# Altium schematic sheet Protection circuit.SchDoc
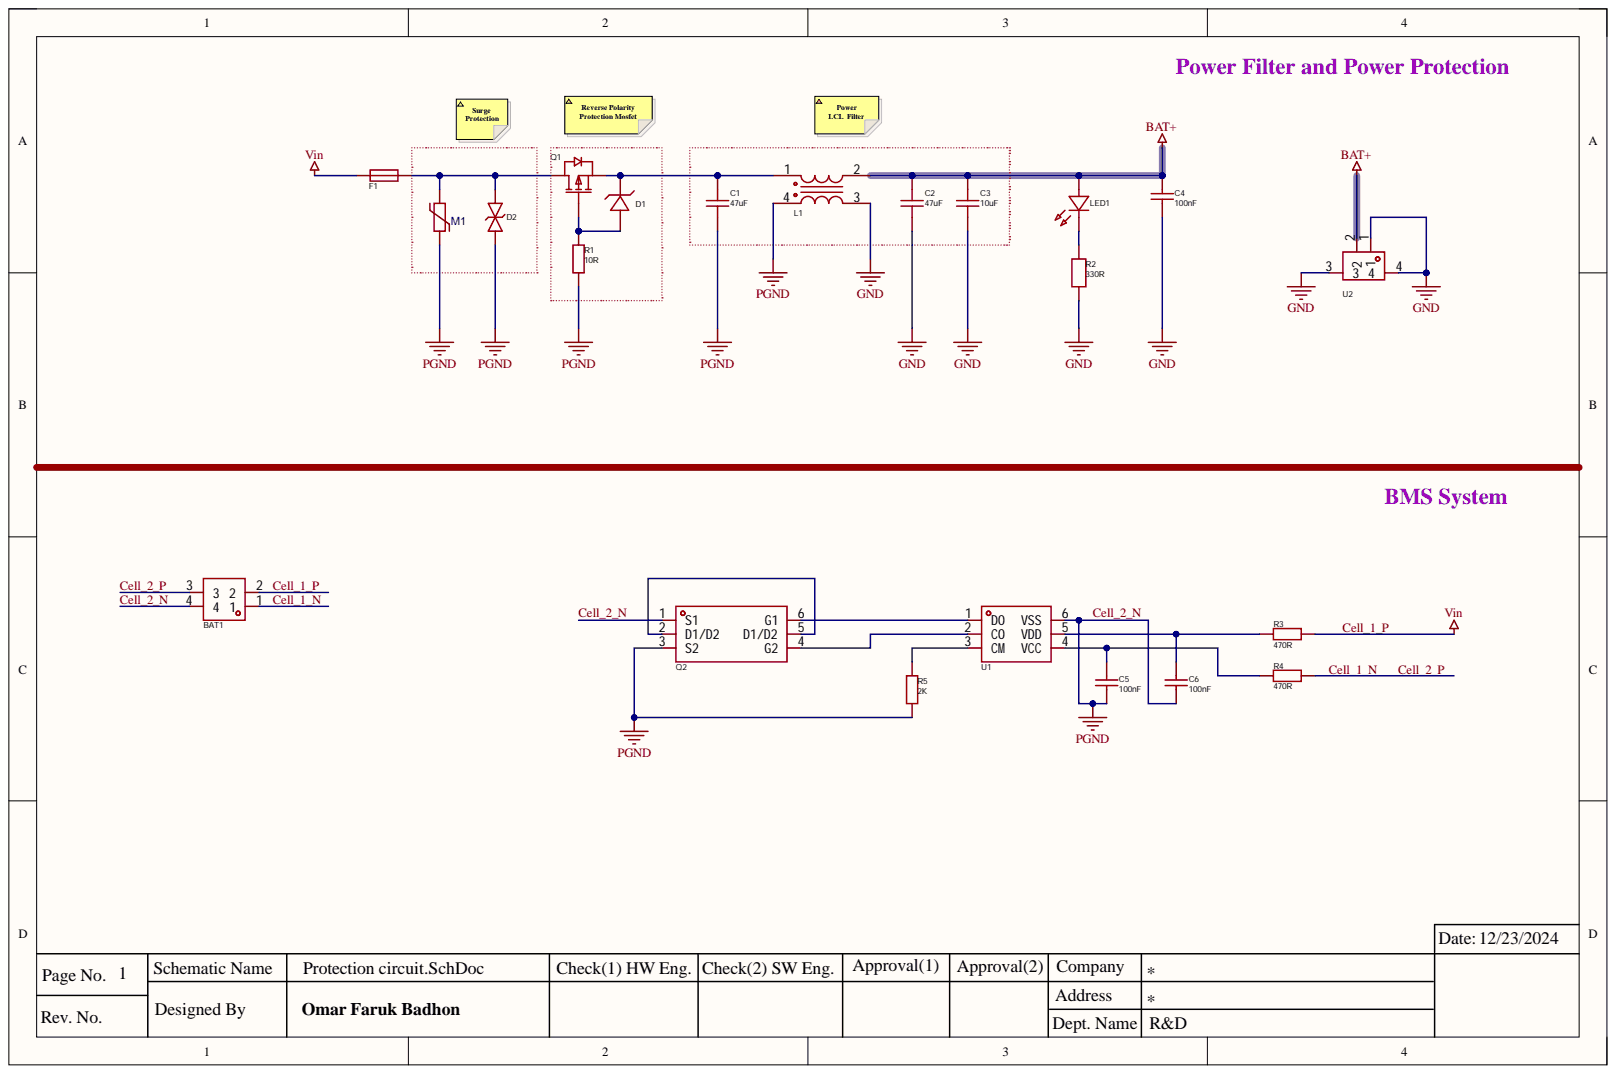
[diagram p0: Protection circuit.SchDoc]

## Parts
BAT1  BH-18650-A1BJ021  [2 Cell Battery Case]  (value not drawn)
C1  47uF  [47uF Cap]
C2  47uF  [47uF Cap]
C3  10uF  [10uF Cap]
C4  100nF  [100nF Cap]
C5  100nF  [100nF Cap]
C6  100nF  [100nF Cap]
D1  BZT52B6V8  [Zener Diode]  (value not drawn)
D2  SDD32C24L01  [ESD Diode]  (value not drawn)
F1  C05228-000  [Fuse_2]  (value not drawn)
L1  CMF4532F-900-2P-Tms  [CMC Filter]  (value not drawn)
LED1  XL-1608SURC-06  [Led]  (value not drawn)
M1  EZJP0V270EA  [Varistor]  (value not drawn)
Q1  AO3401A  [P Mos]  (value not drawn)
Q2  FS8205A  [2 N Mos]  (value not drawn)
R1  10R  [10R Res]
R2  330R  [330R Res]
R3  470R  [470R Res]
R4  470R  [470R Res]
R5  2K  [2K Res]
U1  S-8252AAG-M6T1U  [BMS]  (value not drawn)
U2  BX-HA2.54-2PWT  [SMD JST 2P]  (value not drawn)

## Nets
BAT+: C2.2 C3.2 C4.2 L1.2 LED1.2 U2.2
GND: C2.1 C3.1 C4.1 L1.3 R2.1 U2.1 U2.3 U2.4
Cell_1_N: BAT1.1 BAT1.3 R4.2
Cell_1_P: BAT1.2 F1.1 R3.2
Cell_2_N: BAT1.4 C1.1 C5.1 C6.1 D2.1 L1.4 M1.1 Q2.1(S1) Q2.3(S2) R1.1 R5.1 U1.6(VSS)
NetC1_2: C1.2 D1.1 L1.1 Q1.2
NetC5_2: C5.2 R4.1 U1.4(VCC)
NetC6_2: C6.2 R3.1 U1.5(VDD)
NetD1_2: D1.2 Q1.1 R1.2
NetD2_2: D2.2 F1.2 M1.2 Q1.3
NetLED1_1: LED1.1 R2.2
NetQ2_2: Q2.2(D1/D2) Q2.5(D1/D2)
NetQ2_4: Q2.4(G2) U1.2(CO)
NetQ2_6: Q2.6(G1) U1.1(DO)
NetR5_2: R5.2 U1.3(CM)

## Library data of the parts
BAT1 = 2 cell Battery Case: Manufacturer: MYOUNG(美阳); Add into BOM: yes; Battery Type: CR18650; Connector Type: Battery box; Component Kind: Standard; Contact Plating: Nickel; Convert to PCB: yes; Datasheet: https://atta.szlcsc.com/upload/public/pdf/source/20240112/147D77B47FAFC966051AFF7CD950677D.pdf; Device: BH-18650-A1BJ021; JLCPCB Part Class: Extended Part; LCSC Part Name: 电池盒 适用电池:CR18650; Library Name: Battery circuit sch.SchLib; Manufacturer Part: BH-18650-A1BJ021; Operating Temperature Range: -25℃~+85℃; Supplier: LCSC; Supplier Footprint: 插件; Supplier Part: C20606804; Symbol: BH-18650-A1BJ021
C1 C2 = 47uF Res: Manufacturer: IHHEC(禾伸堂); Add into BOM: yes; Device: C1206B476M016T; Tolerance: ±20%; Component Kind: Standard; Convert to PCB: yes; Datasheet: https://atta.szlcsc.com/upload/public/pdf/source/20190313/C374174_331C86E4A68CC9C732DA87186AAF7F8A.pdf; JLCPCB Part Class: Extended Part; LCSC Part Name: 47uF ±20% 16V; Library Name: Battery circuit sch.SchLib; Manufacturer Part: C1206B476M016T; Supplier: LCSC; Supplier Footprint: 1206; Supplier Part: C607993; Symbol: C1206B476M016T; Value: 47uF
C3 = 10uF Cap: Manufacturer: muRata(村田); Add into BOM: yes; Device: GRM32ER61C106KA12L; Tolerance: ±10%; Component Kind: Standard; Convert to PCB: yes; Datasheet: https://atta.szlcsc.com/upload/public/pdf/source/20200305/C490387_23319AE8C154DEB24C505E6C82A8106A.pdf; JLCPCB Part Class: Extended Part; LCSC Part Name: 10uF ±10% 16V; Library Name: Battery circuit sch.SchLib; Manufacturer Part: GRM32ER61C106KA12L; Supplier: LCSC; Supplier Footprint: 1210; Supplier Part: C184907; Symbol: GRM32ER61C106KA12L; Value: 10uF
C4-C6 = 100nF Cap: Manufacturer: muRata(村田); Add into BOM: yes; Device: GRM188R71C104KA01D; Tolerance: ±10%; Component Kind: Standard; Convert to PCB: yes; Datasheet: https://atta.szlcsc.com/upload/public/pdf/source/20161108/1478586365848.pdf; JLCPCB Part Class: Extended Part; LCSC Part Name: 100nF ±10% 16V; Library Name: Battery circuit sch.SchLib; Manufacturer Part: GRM188R71C104KA01D; Supplier: LCSC; Supplier Footprint: 0603; Supplier Part: C45000; Symbol: GRM188R71C104KA01D; Value: 100nF
D1 = Zener Diode: Manufacturer: FMS(美丽微); Add into BOM: yes; Device: BZT52B6V8_C213147; Diode Configuration: Single; Power Dissipation: 350mW; Reverse Leakage Current (Ir): 2uA@4V; Zener Impedance (Zzt): 15Ω; Zener Voltage (Nom): 6.8V; Zener Voltage (Range): 6.66V~6.94V; Component Kind: Standard; Convert to PCB: yes; Datasheet: https://atta.szlcsc.com/upload/public/pdf/source/20180504/C213215_D8AFED6AF7B069D42DB4E73D6157B86A.pdf; JLCPCB Part Class: Extended Part; LCSC Part Name: BZT52B6V8; Library Name: Battery circuit sch.SchLib; Manufacturer Part: BZT52B6V8; Supplier: LCSC; Supplier Footprint: SOD-123; Supplier Part: C213147; Symbol: BZT52B6V8
D2 = ESD Diode: Manufacturer: Brightking(君耀电子); Add into BOM: yes; Breakdown Voltage: 26.7V; Maximum Clamping Voltage: 43V; Number of Lines: 单路; Peak Pulse Current (Ipp)@10/1000us: 1A; polarity: Bi-Directional; Reverse Stand-Off Voltage (Vrwm): 24V; Type: ESD; Component Kind: Standard; Convert to PCB: yes; Datasheet: https://atta.szlcsc.com/upload/public/pdf/source/20181015/C313315_2E77C22BCD6B5C9557E0D87DB90BD0FE.pdf; Device: SDD32C24L01; JLCPCB Part Class: Extended Part; LCSC Part Name: 双向ESD; Library Name: Battery circuit sch.SchLib; Manufacturer Part: SDD32C24L01; Supplier: LCSC; Supplier Footprint: SOD-323; Supplier Part: C313315; Symbol: SDD32C24L01
F1 = Fuse_2: Manufacturer: Littelfuse(美国力特); Add into BOM: yes; Breaking Capacity: 45A; Current Rating: 5A; Type: SMD Fuse; Voltage Rating (DC): 32V; Component Kind: Standard; Convert to PCB: yes; Datasheet: https://atta.szlcsc.com/upload/public/pdf/source/20191009/C434710_3F89FEC5E8B25E469DD927D36E88D4C3.pdf; Device: C05228-000; JLCPCB Part Class: Extended Part; LCSC Part Name: 贴片式保险丝; Library Name: Battery circuit sch.SchLib; Manufacturer Part: C05228-000; Operating Temperature: -55℃~+125℃; Supplier: LCSC; Supplier Footprint: 1206; Supplier Part: C207129; Symbol: C05228-000
L1 = CMC Filter: Manufacturer: EMTEK(兆欣); Add into BOM: yes; Current Rating: 2A; DC Resistance (DCR): 50mΩ; Impedance @ Frequency: 90Ω@100MHz; Number of Lines: 2; Voltage Rating - DC: 50V; Withstanding Voltage: 12.5V; Component Kind: Standard; Convert to PCB: yes; Datasheet: https://atta.szlcsc.com/upload/public/pdf/source/20190322/C252150_370767FB0BF4DE5D3F867A1ABBD704E0.pdf; Device: CMF4532F-900-2P-Tms; JLCPCB Part Class: Extended Part; LCSC Part Name: 两路 贴片共模滤波器 阻抗90Ω@100MHz; Library Name: Battery circuit sch.SchLib; Manufacturer Part: CMF4532F-900-2P-Tms; Operating Temperature: -40℃~+125℃; Supplier: LCSC; Supplier Footprint: SMD,4.5x3.2x2.8mm; Supplier Part: C252150; Symbol: CMF4532F-900-2P-TMS
LED1 = Led: Manufacturer: XINGLIGHT(成兴光); Add into BOM: yes; Dominant Wavelength: 617nm~621nm; Emitted Color: Red; Forward Current: 25mA; Lens Color: 无色透明透镜; Mounting Sytle: Positive post; Peak Wavelength: 623nm; Power Dissipation: 55mW; Viewing Angle: 120°; Color Temperature: -; Component Kind: Standard; Convert to PCB: yes; Datasheet: https://atta.szlcsc.com/upload/public/pdf/source/20221102/D860AFDD1CDB02C5A8064103A32707E2.pdf; Device: XL-1608SURC-06; JLCPCB Part Class: Extended Part; LCSC Part Name: XL-1608SURC-06; Library Name: Battery circuit sch.SchLib; Manufacturer Part: XL-1608SURC-06; Operating Temperature: -30℃~+85℃; Supplier: LCSC; Supplier Footprint: 0603; Supplier Part: C965799; Symbol: XL-1608SURC-06
M1 = Varistor: Manufacturer: PANASONIC(松下); Contributor: LCSC; Component Kind: Standard; JLCPCB Part Class: Extended Part; Library Name: Battery circuit sch.SchLib; Manufacturer Part: EZJP0V270EA; spicePre: R; spiceSymbolName: EZJP0V270EA; Supplier: LCSC; Supplier Part: C336822
Q1 = P Mos: Manufacturer: AOS; Add into BOM: yes; Device: AO3401A_C15127; Drain Source On Resistance (RDS(on)@Vgs,Id): 44mΩ@10V,4.3A; Gate Threshold Voltage (Vgs(th)@Id): 1.3V@250uA; Input Capacitance (Ciss@Vds): 1.2nF@15V; Total Gate Charge (Qg@Vgs): 12.2nC@4.5V; Type: 1个PChannel; Component Kind: Standard; Convert to PCB: yes; Datasheet: https://atta.szlcsc.com/upload/public/pdf/source/20160507/1462613609053.pdf; JLCPCB Part Class: Basic Part; LCSC Part Name: 1个P沟道 耐压:30V 电流:4A; Library Name: Battery circuit sch.SchLib; Manufacturer Part: AO3401A; Operating Temperature: -55℃~+150℃@(Tj); Supplier: LCSC; Supplier Footprint: SOT-23; Supplier Part: C15127; Symbol: AO3401A
Q2 = 2 N Mos: Manufacturer: TECH PUBLIC(台舟); Add into BOM: yes; Device: FS8205A_C2830320; Drain Source On Resistance (RDS(on)@Vgs,Id): 19.5mΩ@4.5V,3A; Gate Threshold Voltage (Vgs(th)@Id): 700mV@250uA; Input Capacitance (Ciss@Vds): 466pF@10V; Reverse Transfer Capacitance (Crss@Vds): 58pF@10V; Total Gate Charge (Qg@Vgs): 5.7nC@10V; Type: 2 N-Channel; Component Kind: Standard; Convert to PCB: yes; Datasheet: https://atta.szlcsc.com/upload/public/pdf/source/20210810/F53B6D4E8755A1F8E11BAF814CE98E6A.pdf; JLCPCB Part Class: Extended Part; LCSC Part Name: 2个N沟道 耐压:20V 电流:6A; Library Name: Battery circuit sch.SchLib; Manufacturer Part: FS8205A; Operating Temperature: -55℃~+150℃@(Tj); Supplier: LCSC; Supplier Footprint: SOT-23-6; Supplier Part: C2830320; Symbol: FS8205A_C2830320
R1 = 10R Res: Manufacturer: FH(风华); Add into BOM: yes; Device: RS-03K100JT; Temperature Coefficient: ±100ppm/℃; Tolerance: ±5%; Value: 10Ω; Component Kind: Standard; Convert to PCB: yes; Datasheet: https://atta.szlcsc.com/upload/public/pdf/source/20190505/C118335_36085569433BA7FFC796E569DBFE3139.pdf; JLCPCB Part Class: Extended Part; LCSC Part Name: 厚膜电阻 10Ω ±5% 100mW; Library Name: Battery circuit sch.SchLib; Manufacturer Part: RS-03K100JT; Operating Temperature Range: -55℃~+155℃; Supplier: LCSC; Supplier Footprint: 0603; Supplier Part: C304686; Symbol: RS-03K100JT; Type: Thick Film Resistors
R2 = 330R Res: Manufacturer: PANASONIC(松下); Add into BOM: yes; Device: ERJPA2F3300X; Temperature Coefficient: ±100ppm/℃; Tolerance: ±1%; Value: 330Ω; Component Kind: Standard; Convert to PCB: yes; JLCPCB Part Class: Extended Part; LCSC Part Name: 330Ω ±1% 200mW 厚膜电阻; Library Name: Battery circuit sch.SchLib; Manufacturer Part: ERJPA2F3300X; Operating Temperature Range: -55℃~+155℃; Overload Voltage (Max): -; Supplier: LCSC; Supplier Footprint: 0402; Supplier Part: C427237; Symbol: ERJPA2F3300X; Type: Thick Film Resistors
R3 R4 = 470R Res: Manufacturer: LIZ(丽智电子); Add into BOM: yes; Device: CR0402FF4700G; Temperature Coefficient: ±200ppm/℃; Tolerance: ±1%; Value: 470Ω; Component Kind: Standard; Convert to PCB: yes; Datasheet: https://atta.szlcsc.com/upload/public/pdf/source/20180403/C189438_6D658388CC7843F35BBC9018D03F0B8E.pdf; JLCPCB Part Class: Extended Part; LCSC Part Name: 厚膜电阻 470Ω ±1% 62.5mW; Library Name: Battery circuit sch.SchLib; Manufacturer Part: CR0402FF4700G; Operating Temperature Range: -55℃~+125℃; Supplier: LCSC; Supplier Footprint: 0402; Supplier Part: C100443; Symbol: CR0402FF4700G; Type: Thick Film Resistors
R5 = 2K Res: Manufacturer: FH(风华); Add into BOM: yes; Device: RC-02W2001FT; Temperature Coefficient: ±200ppm/℃; Tolerance: ±1%; Value: 2kΩ; Component Kind: Standard; Convert to PCB: yes; Datasheet: https://atta.szlcsc.com/upload/public/pdf/source/20190505/C118335_36085569433BA7FFC796E569DBFE3139.pdf; JLCPCB Part Class: Extended Part; LCSC Part Name: 厚膜电阻 2kΩ ±1% 62.5mW; Library Name: Battery circuit sch.SchLib; Manufacturer Part: RC-02W2001FT; Operating Temperature Range: -55℃~+155℃; Supplier: LCSC; Supplier Footprint: 0402; Supplier Part: C304583; Symbol: RC-02W2001FT; Type: Thick Film Resistors
U1 = BMS: Manufacturer: ABLIC(艾普凌科); Add into BOM: yes; Number of Cells: 2; The Chip Type: 电池保护芯片; Type of Battery: 锂离子/Polymer; Component Kind: Standard; Convert to PCB: yes; Datasheet: https://atta.szlcsc.com/upload/public/pdf/source/20191209/C468225_F5C4BE1FF1893F617C569592E38D23FF.pdf; Device: S-8252AAG-M6T1U; JLCPCB Part Class: Extended Part; LCSC Part Name: S-8252AAG-M6T1U; Library Name: Battery circuit sch.SchLib; Manufacturer Part: S-8252AAG-M6T1U; Operating Temperature: -40℃~+85℃; Supplier: LCSC; Supplier Footprint: SOT-23-6; Supplier Part: C468221; Symbol: S-8252AAG-M6T1U
U2 = SMD JST: Manufacturer: Bossie(博锡); Add into BOM: yes; Current Rating (Max): 3A; Number of PINs Per Row: 2; Pins Structure: 1x2P; Pitch: 2.54mm; Component Kind: Standard; Contact Material: Brass; Contact Plating: Tin; Convert to PCB: yes; Datasheet: https://atta.szlcsc.com/upload/public/pdf/source/20231017/0F5BE3E474C97FE56F69CCCD4551F1BC.pdf; Device: BX-HA2.54-2PWT; JLCPCB Part Class: Extended Part; LCSC Part Name: 1x2P 间距:2.54mm 卧贴; Library Name: Battery circuit sch.SchLib; Manufacturer Part: BX-HA2.54-2PWT; Mounting Style: 卧贴; Number of Pins: 2P; Number of Rows: 1; Operating Temperature Range: -25℃~+85℃; Reference Series: -; Row Spacing: -; Supplier: LCSC; Supplier Footprint: SMD,P=2.54mm,卧贴; Supplier Part: C18077806; Symbol: BX-HA2.54-2PWT
